# Altium schematic sheet ELRS_E19.SchDoc
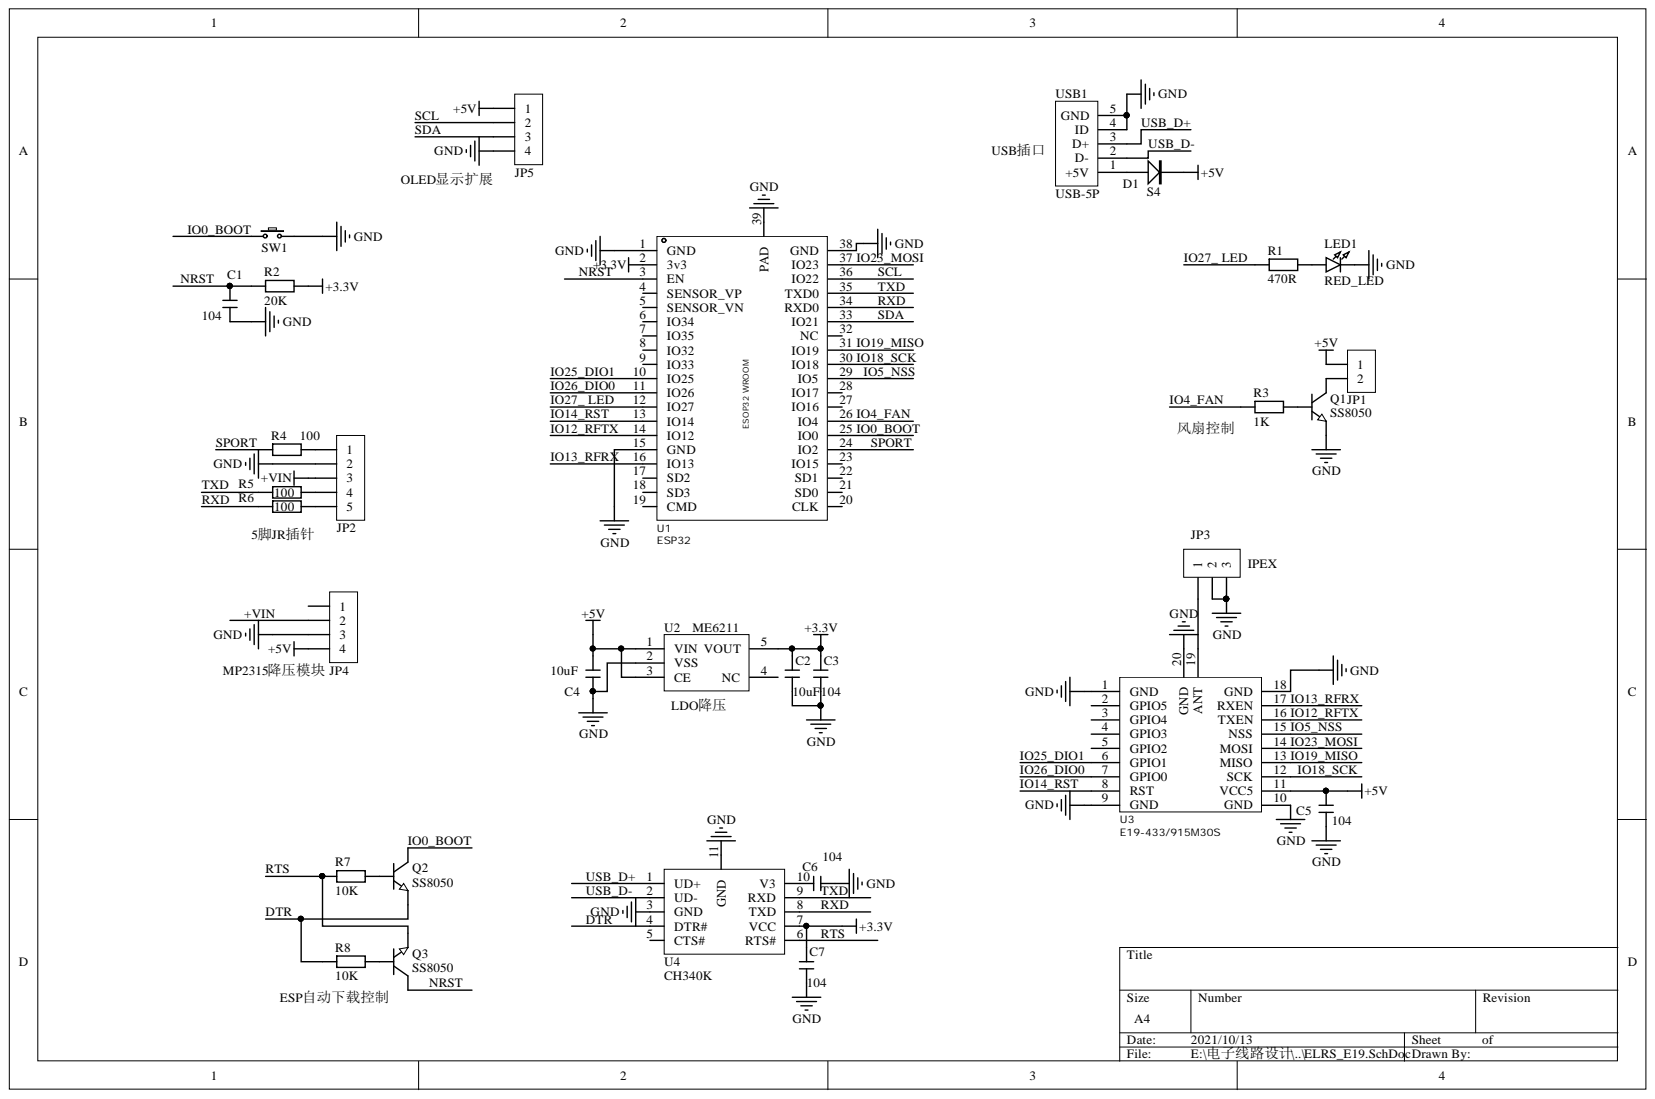
[diagram p0: ELRS_E19.SchDoc]

## Parts
C1  104  [C 0603_L]
C2  10uF  [C 0805_L]
C3  104  [C 0603_L]
C4  10uF  [C 0805_L]
C5  104  [C 0603_L]
C6  104  [C 0603_L]
C7  104  [C 0603_L]
D1  S4  [SOD123]
JP1  HDR-1X2  [PH2.0-2]  (value not drawn)
JP2  HDR-1X5  [B2541BW-5P]  (value not drawn)
JP3  HDR-1X3  [IPEX]  (value not drawn)
JP4  HDR-1X4  [MP2315-MODULE-SMT]  (value not drawn)
JP5  HDR-1X4  [J-LINK]  (value not drawn)
LED1  RED_LED  [LED0805TB]
Q1  SS8050  [SOT23-3L]
Q2  SS8050  [SOT23-3L]
Q3  SS8050  [SOT23-3L]
R1  470R  [R 0603_L]
R2  20K  [R 0603_L]
R3  1K  [R 0603_L]
R4  100  [R 0603_L]
R5  100  [R 0603_L]
R6  100  [R 0603_L]
R7  10K  [R 0603_L]
R8  10K  [R 0603_L]
SW1  FLASH  [KEY-K2]  (value not drawn)
U1  ESP32  [ESP32]
U2  ME6211  [SOT23-5M]
U3  E19-433/915M30S  [E19_2IN1]
U4  CH340K  [CH340K]
USB1  USB-5P  [USB-micro_C]

## Nets
+3.3V: C2.2 C3.2 C7.2 R2.1 U1.2(3v3) U2.5(VOUT) U4.7(VCC)
+5V: C4.2 C5.2 D1.2 JP1.1 JP4.4 JP5.1 U2.1(VIN) U2.3(CE) U3.11(VCC5)
GND: C1.1 C2.1 C3.1 C4.1 C5.1 C6.1 C7.1 JP2.2 JP3.2 JP3.3 JP4.3 JP5.4 LED1.2 Q1.2 SW1.2 U1.1(GND) U1.15(GND) U1.38(GND) U1.39(PAD) U2.2(VSS) U3.1(GND) U3.9(GND) U3.10(GND) U3.18(GND) U3.20(GND) U4.3(GND) U4.11(GND) USB1.4(ID) USB1.5(GND)
+VIN: JP2.3 JP4.2
DTR: Q2.2 R8.2 U4.4(DTR#)
IO0_BOOT: Q2.3 SW1.1 U1.25(IO0)
IO4_FAN: R3.2 U1.26(IO4)
IO5_NSS: U1.29(IO5) U3.15(NSS)
IO12_RFTX: U1.14(IO12) U3.16(TXEN)
IO13_RFRX: U1.16(IO13) U3.17(RXEN)
IO14_RST: U1.13(IO14) U3.8(RST)
IO18_SCK: U1.30(IO18) U3.12(SCK)
IO19_MISO: U1.31(IO19) U3.13(MISO)
IO23_MOSI: U1.37(IO23) U3.14(MOSI)
IO25_DIO1: U1.10(IO25) U3.6(GPIO1)
IO26_DIO0: U1.11(IO26) U3.7(GPIO0)
IO27_ LED: R1.2 U1.12(IO27)
NRST: C1.2 Q3.3 R2.2 U1.3(EN)
RTS: Q3.2 R7.2 U4.6(RTS#)
RXD: R6.2 U1.34(RXD0) U4.8(TXD)
SCL: JP5.2 U1.36(IO22)
SDA: JP5.3 U1.33(IO21)
SPORT: R4.2 U1.24(IO2)
TXD: R5.2 U1.35(TXD0) U4.9(RXD)
USB_D+: U4.1(UD+) USB1.3(D+)
USB_D-: U4.2(UD-) USB1.2(D-)
NetC6_2: C6.2 U4.10(V3)
NetD1_1: D1.1 USB1.1(+5V)
NetJP1_2: JP1.2 Q1.3
NetJP2_1: JP2.1 R4.1
NetJP2_4: JP2.4 R5.1
NetJP2_5: JP2.5 R6.1
NetJP3_1: JP3.1 U3.19(ANT)
NetLED1_1: LED1.1 R1.1
NetQ1_1: Q1.1 R3.1
NetQ2_1: Q2.1 R7.1
NetQ3_1: Q3.1 R8.1

Not connected: JP4 1; U1 4(SENSOR_VP) 5(SENSOR_VN) 6(IO34) 7(IO35) 8(IO32) 9(IO33) 17(SD2) 18(SD3) 19(CMD) 20(CLK) 21(SD0) 22(SD1) 23(IO15) 27(IO16) 28(IO17) 32(NC); U2 4(NC); U3 2(GPIO5) 3(GPIO4) 4(GPIO3) 5(GPIO2); U4 5(CTS#)

## Library data of the parts
C1-C7 = C: 无极性贴片电容; 版权归属: 源创客; BOM名称: 无极性贴片电容; Component Kind: Standard; Library Name: 3.1   - 电阻-电容-电感.SchLib; 学习资源: www.ooocke.com
D1 = SS14: 肖特基二极管; 版权归属: 源创客; 属性: 40V/1A; BOM名称: 肖特基二极管; Component Kind: Standard; Library Name: 8.1   - 二极管-整流桥.SchLib; 学习资源: www.ooocke.com
JP1 = Header 2: 2P接插件; 版权归属: 源创客; BOM名称: 2P接插件; Component Kind: Standard; Library Name: 4.3   - 接插件-脚距1.5.SchLib; 学习资源: www.ooocke.com
JP2 = Header 5: 5P接插件; 版权归属: 源创客; BOM名称: 5P接插件; Component Kind: Standard; Library Name: 4.5   - 接插件-脚距2.54.SchLib; 学习资源: www.ooocke.com
JP3 = Header 3: 3P接插件; 版权归属: 源创客; BOM名称: 3P接插件; Component Kind: Standard; Library Name: 4.3   - 接插件-脚距1.5.SchLib; 学习资源: www.ooocke.com
JP4 JP5 = Header 4: 4P接插件; 版权归属: 源创客; BOM名称: 4P接插件; Component Kind: Standard; Library Name: 4.5   - 接插件-脚距2.54.SchLib; 学习资源: www.ooocke.com
LED1 = LED-SMD: 贴片LED; 版权归属: 源创客; BOM名称: 贴片LED; Component Kind: Standard; Library Name: 9.1   - LED.SchLib; 学习资源: www.ooocke.com
Q1-Q3 = 8050-SMD: 高频放大-NPN型; 版权归属: 源创客; 属性: 40V 1.5A 1W 100MHZ; BOM名称: 高频放大-NPN型; Component Kind: Standard; Library Name: 6.2   - 三极管.SchLib; 学习资源: www.ooocke.com
R1-R8 = R: 贴片电阻; 版权归属: 源创客; BOM名称: 贴片电阻; Component Kind: Standard; Library Name: 3.1   - 电阻-电容-电感.SchLib; 学习资源: www.ooocke.com
SW1 = TSW DIP-6x6_V: 侧按直插6x6轻触开关; 版权归属: 源创客; BOM名称: 直插6x6轻触开关; Component Kind: Standard; Library Name: 5.1   - 按键-开关.SchLib; 学习资源: www.ooocke.com
U3 = LORA-SX1276M: Component Kind: Standard; Library Name: Schlib.SchLib
USB1 = USB-5P: 微型USB母座; 版权归属: 源创客; BOM名称: 微型USB母座; Component Kind: Standard; Library Name: 4.1   - 接口类.SchLib; 学习资源: www.ooocke.com
U1 = ESP32: Component Kind: Standard; Library Name: Schlib.SchLib
U2 = ME6211: Component Kind: Standard; Library Name: MINI.SCHLIB
U4 = CH340K: Component Kind: Standard; Library Name: Schlib.SchLib
